# Altium schematic sheet UserInterfejs.SchDoc(UserInterfejs)
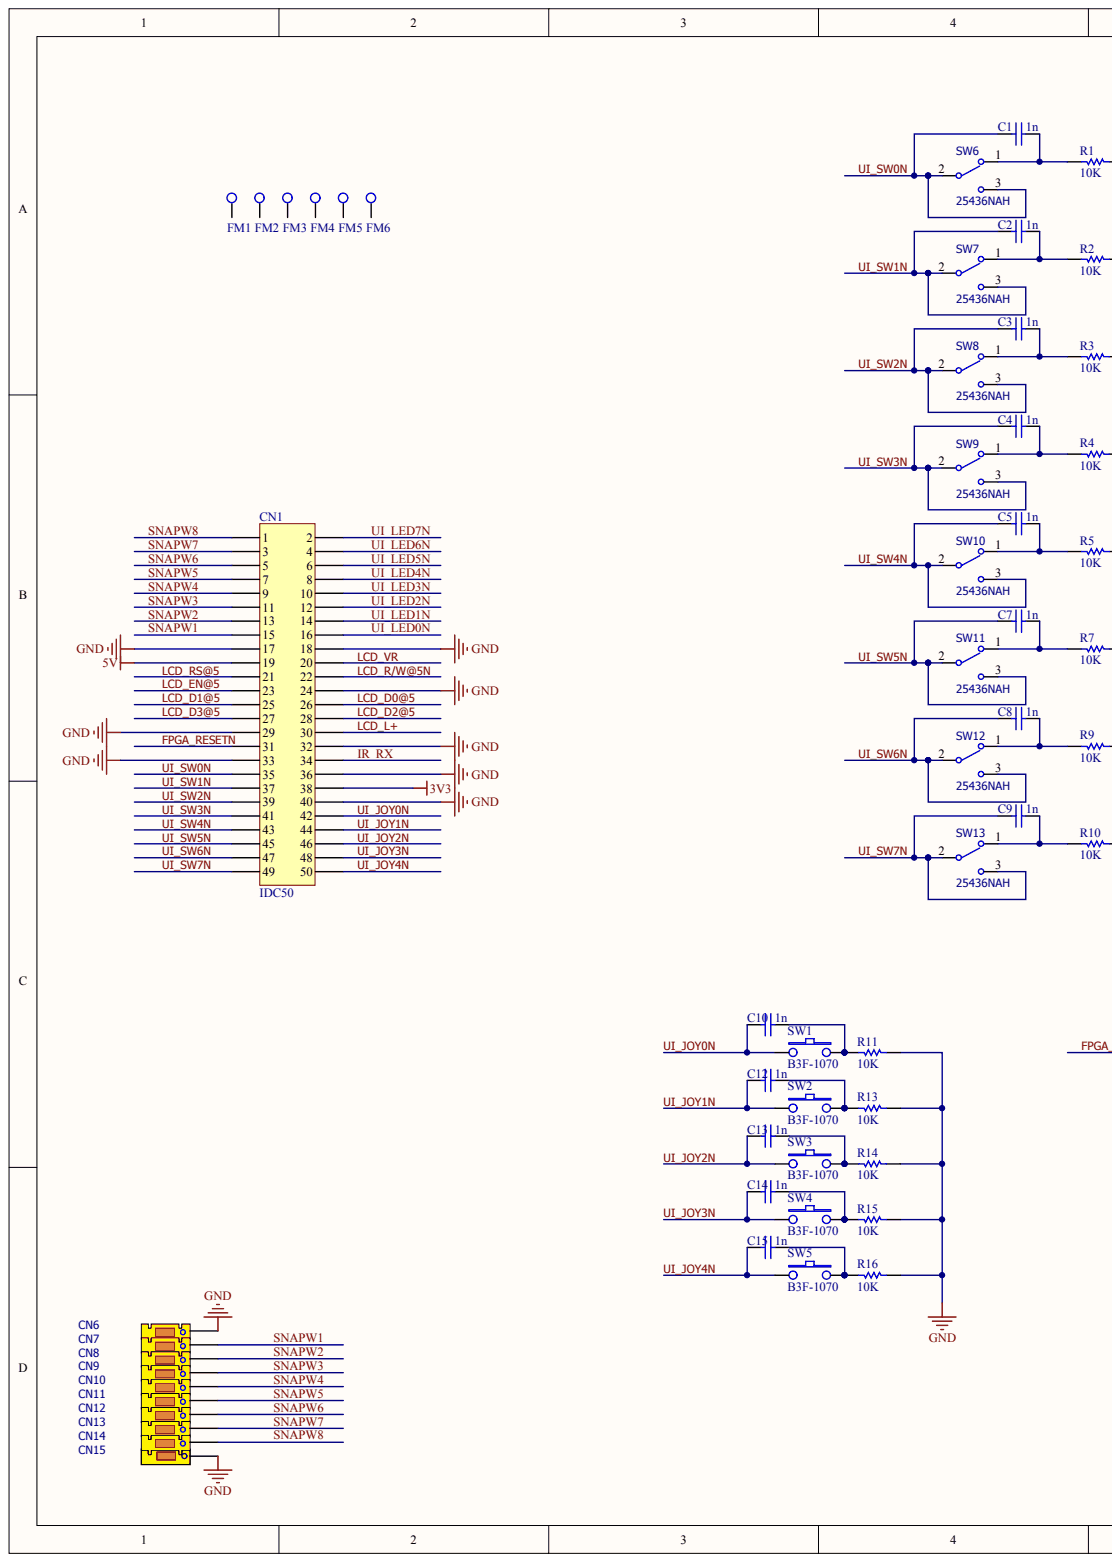
[diagram p0: UserInterfejs.SchDoc, left]
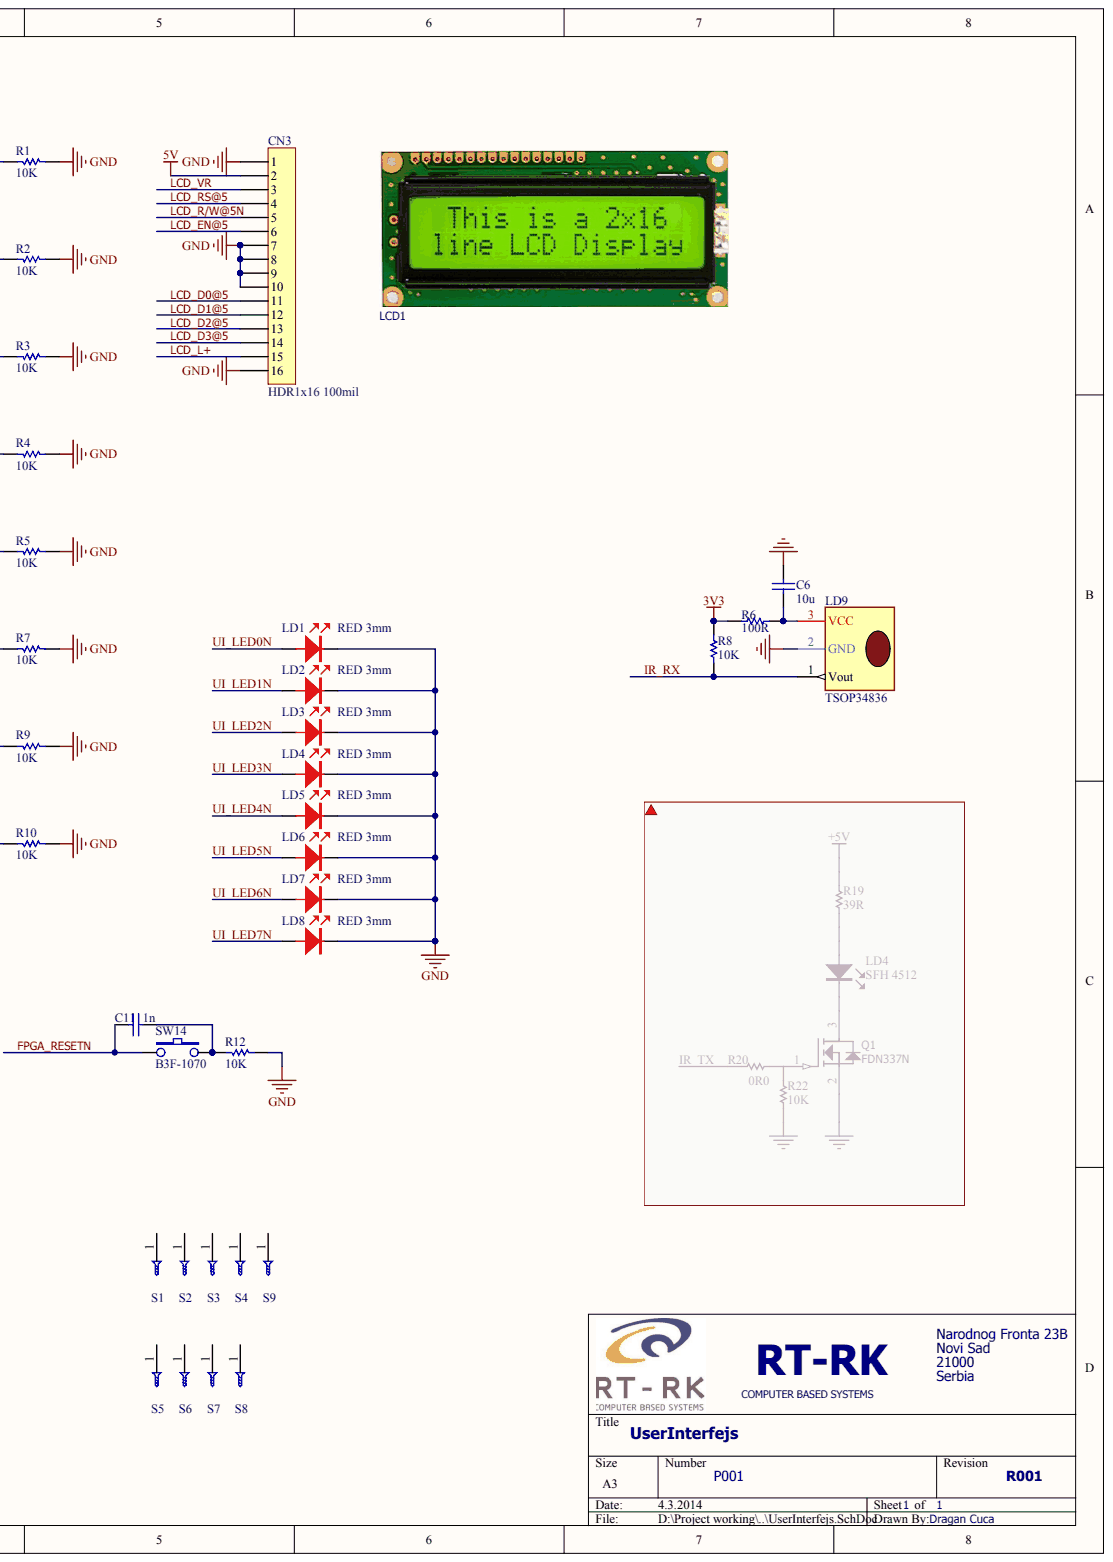
[diagram p1: UserInterfejs.SchDoc, right]

## Parts
C1  1n
C2  1n
C3  1n
C4  1n
C5  1n
C6  10u
C7  1n
C8  1n
C9  1n
C10  1n
C11  1n
C12  1n
C13  1n
C14  1n
C15  1n
CN1  IDC50
CN3  HDR1x16 100mil
CN6  Terminal Block  (value not drawn)
CN7  Terminal Block  (value not drawn)
CN8  Terminal Block  (value not drawn)
CN9  Terminal Block  (value not drawn)
CN10  Terminal Block  (value not drawn)
CN11  Terminal Block  (value not drawn)
CN12  Terminal Block  (value not drawn)
CN13  Terminal Block  (value not drawn)
CN14  Terminal Block  (value not drawn)
CN15  Terminal Block  (value not drawn)
FM1  =Visible
FM2  =Visible
FM3  =Visible
FM4  =Visible
FM5  =Visible
FM6  =Visible
LCD1  LCD 2x16  (value not drawn)
LD1  RED 3mm
LD2  RED 3mm
LD3  RED 3mm
LD4  RED 3mm
LD5  RED 3mm
LD6  RED 3mm
LD7  RED 3mm
LD8  RED 3mm
LD9  TSOP34836
R1  10K
R2  10K
R3  10K
R4  10K
R5  10K
R6  100R
R7  10K
R8  10K
R9  10K
R10  10K
R11  10K
R12  10K
R13  10K
R14  10K
R15  10K
R16  10K
S1  Plated, 3.5mm Hole, 6mm Land  (value not drawn)
S2  Plated, 3.5mm Hole, 6mm Land  (value not drawn)
S3  Plated, 3.5mm Hole, 6mm Land  (value not drawn)
S4  Plated, 3.5mm Hole, 6mm Land  (value not drawn)
S5  Plated 3.4mm Hole 5mm Land  (value not drawn)
S6  Plated 3.4mm Hole 5mm Land  (value not drawn)
S7  Plated 3.4mm Hole 5mm Land  (value not drawn)
S8  Plated 3.4mm Hole 5mm Land  (value not drawn)
S9  Plated, 3.5mm Hole, 6mm Land  (value not drawn)
SW1  B3F-1070
SW2  B3F-1070
SW3  B3F-1070
SW4  B3F-1070
SW5  B3F-1070
SW6  25436NAH
SW7  25436NAH
SW8  25436NAH
SW9  25436NAH
SW10  25436NAH
SW11  25436NAH
SW12  25436NAH
SW13  25436NAH
SW14  B3F-1070

## Nets
3V3: CN1.38 R6.1 R8.2
5V: CN1.19 CN3.2
GND: C6.2 CN1.17 CN1.18 CN1.24 CN1.29 CN1.32 CN1.33 CN1.36 CN1.40 CN3.1 CN3.7 CN3.8 CN3.9 CN3.10 CN3.16 CN6.1 CN15.1 LD1.1 LD2.1 LD3.1 LD4.1 LD5.1 LD6.1 LD7.1 LD8.1 LD9.2 R1.2 R2.2 R3.2 R4.2 R5.2 R7.2 R9.2 R10.2 R11.2 R12.2 R13.2 R14.2 R15.2 R16.2
FPGA_RESETN: C11.2 CN1.31 SW14.1
IR_RX: CN1.34 LD9.1 R8.1
LCD_D0@5: CN1.26 CN3.11
LCD_D1@5: CN1.25 CN3.12
LCD_D2@5: CN1.28 CN3.13
LCD_D3@5: CN1.27 CN3.14
LCD_EN@5: CN1.23 CN3.6
LCD_L+: CN1.30 CN3.15
LCD_R/W@5N: CN1.22 CN3.5
LCD_RS@5: CN1.21 CN3.4
LCD_VR: CN1.20 CN3.3
SNAPW1: CN1.15 CN7.1
SNAPW2: CN1.13 CN8.1
SNAPW3: CN1.11 CN9.1
SNAPW4: CN1.9 CN10.1
SNAPW5: CN1.7 CN11.1
SNAPW6: CN1.5 CN12.1
SNAPW7: CN1.3 CN13.1
SNAPW8: CN1.1 CN14.1
UI_JOY0N: C10.2 CN1.42 SW1.1
UI_JOY1N: C12.2 CN1.44 SW2.1
UI_JOY2N: C13.2 CN1.46 SW3.1
UI_JOY3N: C14.2 CN1.48 SW4.1
UI_JOY4N: C15.2 CN1.50 SW5.1
UI_LED0N: CN1.16 LD1.2
UI_LED1N: CN1.14 LD2.2
UI_LED2N: CN1.12 LD3.2
UI_LED3N: CN1.10 LD4.2
UI_LED4N: CN1.8 LD5.2
UI_LED5N: CN1.6 LD6.2
UI_LED6N: CN1.4 LD7.2
UI_LED7N: CN1.2 LD8.2
UI_SW0N: C1.1 CN1.35 SW6.2 SW6.3
UI_SW1N: C2.1 CN1.37 SW7.2 SW7.3
UI_SW2N: C3.1 CN1.39 SW8.2 SW8.3
UI_SW3N: C4.1 CN1.41 SW9.2 SW9.3
UI_SW4N: C5.1 CN1.43 SW10.2 SW10.3
UI_SW5N: C7.1 CN1.45 SW11.2 SW11.3
UI_SW6N: C8.1 CN1.47 SW12.2 SW12.3
UI_SW7N: C9.1 CN1.49 SW13.2 SW13.3
NetC1_2: C1.2 R1.1 SW6.1
NetC2_2: C2.2 R2.1 SW7.1
NetC3_2: C3.2 R3.1 SW8.1
NetC4_2: C4.2 R4.1 SW9.1
NetC5_2: C5.2 R5.1 SW10.1
NetC6_1: C6.1 LD9.3 R6.2
NetC7_2: C7.2 R7.1 SW11.1
NetC8_2: C8.2 R9.1 SW12.1
NetC9_2: C9.2 R10.1 SW13.1
NetC10_1: C10.1 R11.1 SW1.2
NetC11_1: C11.1 R12.1 SW14.2
NetC12_1: C12.1 R13.1 SW2.2
NetC13_1: C13.1 R14.1 SW3.2
NetC14_1: C14.1 R15.1 SW4.2
NetC15_1: C15.1 R16.1 SW5.2

Not connected: FM1 1; FM2 1; FM3 1; FM4 1; FM5 1; FM6 1; S1 1; S2 1; S3 1; S4 1; S5 1; S6 1; S7 1; S8 1; S9 1

## Library data of the parts
C1-C5 C7-C15 = (no symbol name): Manufacturer: MURATA; Manufacturer Code: GRM1555C1H102JA01D; Ordering Code: 8819556; Implementation: YES; Value: 1n; Product: KOKE 1N/50 0402-COG; Distributor: Farnell; Knowledge Datasheet: *; Available: YES
C6 = (no symbol name): Manufacturer Code: C0603C106M9PACTU; Manufacturer: KEMET; Ordering Code: 1288201; Position: Q0116; Available: YES; Value: 10u; Product: KOKE 10U/6.3 0603-X5R; Distributor: Farnell; Knowledge Datasheet: *; Implementation: YES
CN1 = (no symbol name): Manufacturer: MULTICOMP; Manufacturer code: MC9A12-5034; Available: YES; Ordering code: 1099262; Distributor: Farnell; Value: IDC50; Implementation: YES
CN3 = (no symbol name): Manufacturer: SPC TECHNOLOGY; Manufacturer code: SPC20484; Implementation: YES; Ordering code: 1462888; Distributor: Farnell; Value: HDR1x16 100mil; Available: YES; Knowledge Datasheet: N/A; Product: CON HEADER .100 1X40 TH V
CN6-CN14 = (no symbol name): Manufacturer: PHOENIX CONTACT; Manufacturer code: 1791813; Ordering code: 277-1312-ND; Implementation: Yes; Distributor: Digi-Key; Product: CON TERMINAL 2.54MM 1X1 V TH PHOENIX FFKDS/V-2.54; Value: Terminal Block
CN15 = (no symbol name): Manufacturer: PHOENIX CONTACT; Manufacturer code: 1791855; Ordering code: 277-1313-ND; Implementation: Yes; Distributor: Digi-Key; Product: CON TERMINAL 2.54MM 1X1 V TH PHOENIX FFKDS/V-2.54; Value: Terminal Block
FM1-FM6 = (no symbol name): Available: YES; Implementation: NO; Value: TP Hole 1mm
LCD1 = (no symbol name): Manufacturer: Display Elektronik GmbH; Ordering code: DEM16216 SYH-LY; Implementation: YES; Distributor: Tagor; Manufacturer code: DEM16216 SYH-LY; Product: HYB LCD DEM16216 SYH-LY DISPLAY; Value: LCD 2x16
LD1-LD8 = (no symbol name): Manufacturer: KINGBRIGHT; Manufacturer Code: L-934LID; Ordering Code: 1142512; Available: YES; Distributor: Farnell; Product: NA; Implementation: YES; value: RED 3mm
LD9 = (no symbol name): Manufacturer: VISHAY; Available: YES; Ordering Code: 4913127; Distributor: Farnell; Implementation: YES; Manufacturer Code: TSOP34836; Product: TR TSOP34836 IR TH; Value: TSOP34836
R1-R5 R7-R16 = (no symbol name): Manufacturer: MULTICOMP; Manufacturer Code: MC 0.063W 0603 1% 10K; Ordering Code: 9330399; Available: YES; Complies Marks: RoHS; Temp. Operating: -55°C to +155°C; Value: 10K; Product: RES 10K 0603 1%; Distributor: Farnell; Implementation: YES; Knowledge Datasheet: http://knowledge/view.php?fDocumentId=23309; Complies Standards: *; Temp Storage: -55°C to +155°C
R6 = (no symbol name): Manufacturer Code: MC 0.0625W 0402 1% 100R; Manufacturer: MULTICOMP; Ordering Code: 1358015; Available: YES; Value: 100R; Product: RES 100R 0402 1%; Distributor: Farnell; Knowledge Datasheet: *; Implementation: YES
S1-S9 = (no symbol name): Implementation: NO; Available: YES
  S1-S4 S9: Value: Plated, 3.5mm Hole, 6mm Land
  S5-S8: Value: Plated 3.4mm Hole 5mm Land
SW1-SW5 SW14 = (no symbol name): Manufacturer: OMRON; Ordering Code: 959698; Implementation: YES; Value: B3F-1070; Product: PB 6x6 V 6MM B3F-1070; Distributor: Farnell; Manufacturer Code: B3F-1070; Available: YES; Knowledge Datasheet: http://knowledge/view.php?fDocumentId=19505
SW6-SW13 = (no symbol name): Manufacturer: APEM; Implementation: YES; Ordering Code: 1607954; Distributor: Farnell; Product: N/A; Manufacturer code: 25436NAH; Available: YES; Value: 25436NAH
